# Altium schematic sheet main.SchDoc
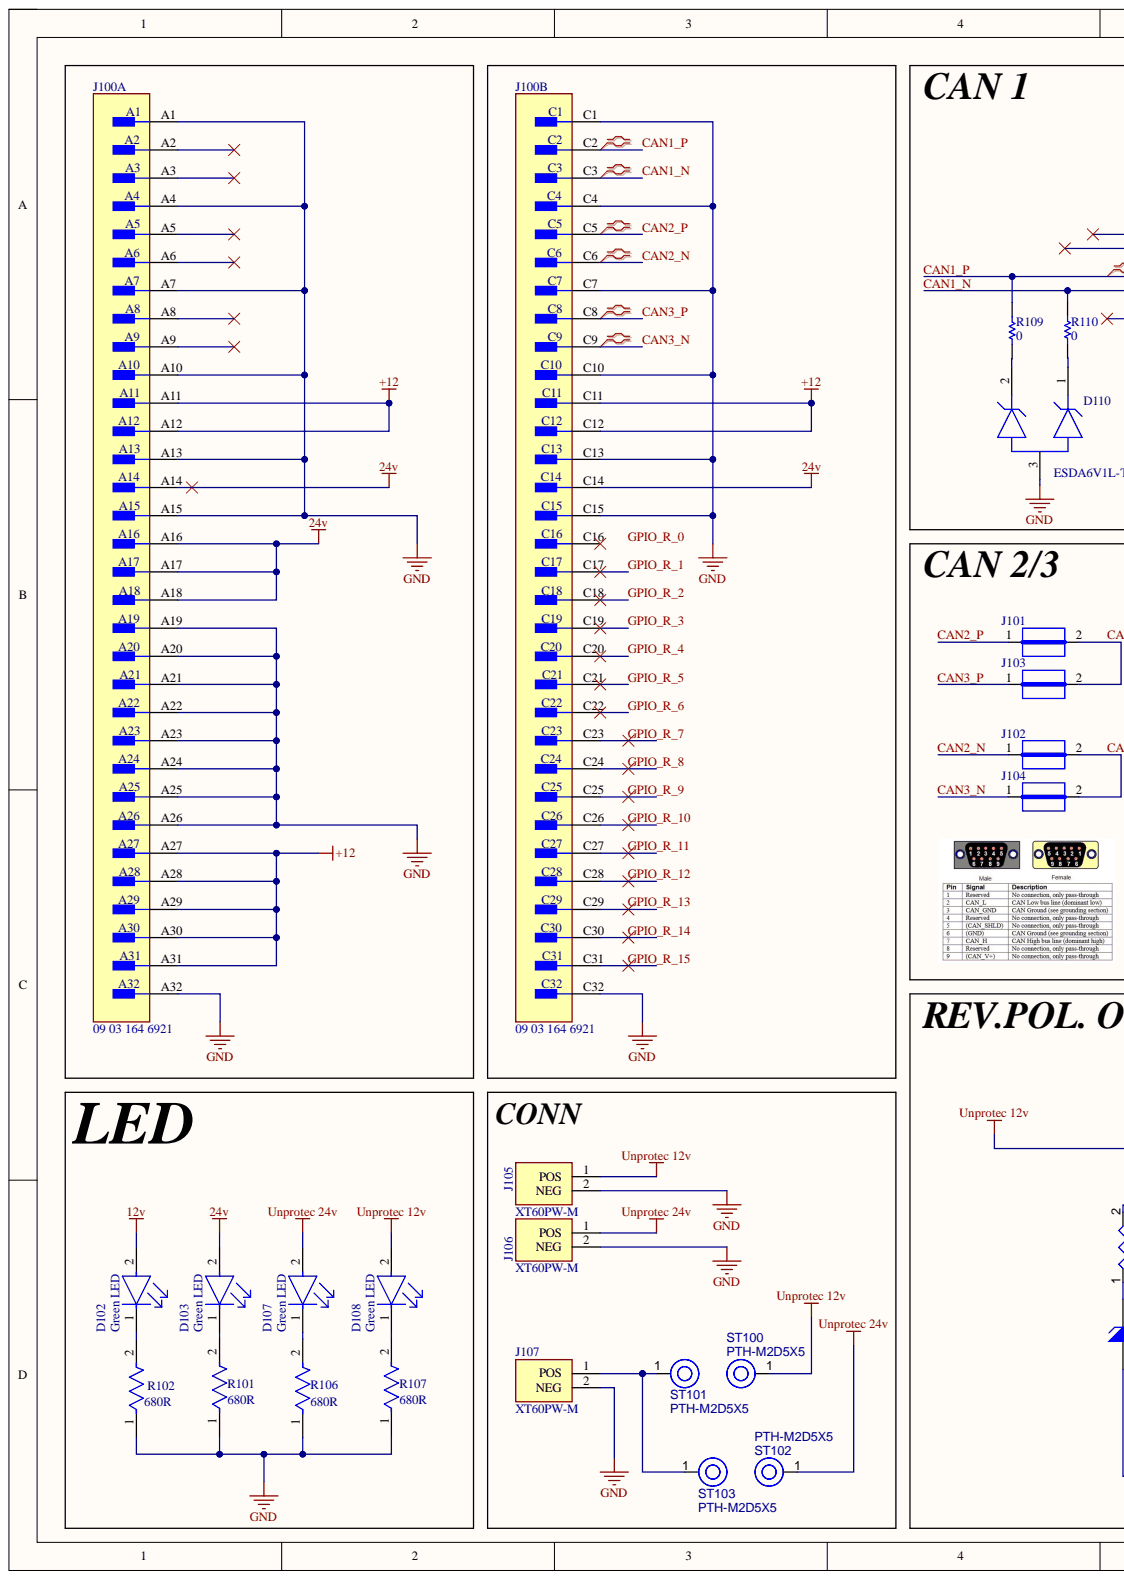
[diagram p0: main.SchDoc, left]
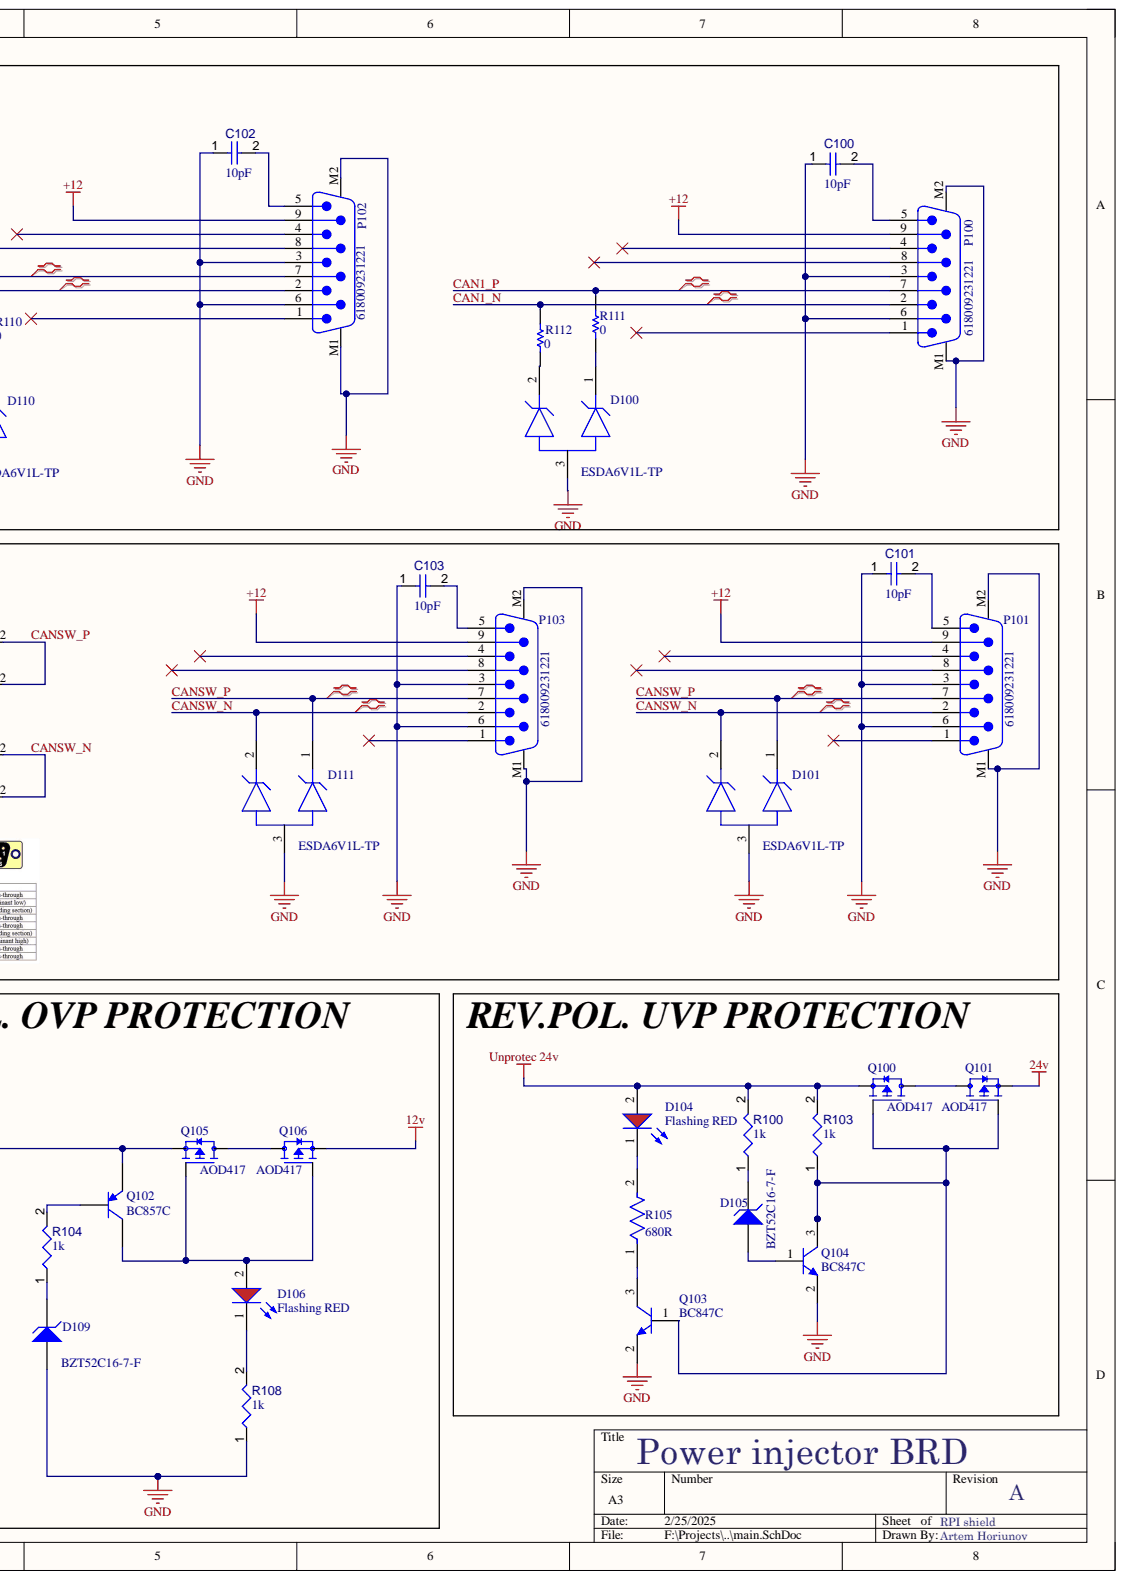
[diagram p1: main.SchDoc, right]

## Parts
C100  value 10pF  [CAPC1005X55X30LL05T10]  (hidden comment: Capacitor 10 pF +/- 0.25 pF 50 V 0402)
C101  value 10pF  [CAPC1005X55X30LL05T10]  (hidden comment: Capacitor 10 pF +/- 0.25 pF 50 V 0402)
C102  value 10pF  [CAPC1005X55X30LL05T10]  (hidden comment: Capacitor 10 pF +/- 0.25 pF 50 V 0402)
C103  value 10pF  [CAPC1005X55X30LL05T10]  (hidden comment: Capacitor 10 pF +/- 0.25 pF 50 V 0402)
D100  ESDA6V1L-TP  [FP-SOT-23-MFG]
D101  ESDA6V1L-TP  [FP-SOT-23-MFG]
D102  Green LED  Colour: Green  [FP-HSME-C191-MFG]
D103  Green LED  Colour: Green  [FP-HSME-C191-MFG]
D104  Flashing RED  [WL-SMCW_0805_150080xx75000]
D105  BZT52C16-7-F  [DIOD-SOD123-2_V]
D106  Flashing RED  [WL-SMCW_0805_150080xx75000]
D107  Green LED  Colour: Green  [FP-HSME-C191-MFG]
D108  Green LED  Colour: Green  [FP-HSME-C191-MFG]
D109  BZT52C16-7-F  [DIOD-SOD123-2_V]
D110  ESDA6V1L-TP  [FP-SOT-23-MFG]
D111  ESDA6V1L-TP  [FP-SOT-23-MFG]
J100  09 03 164 6921  [FP-09_03_164_6921-MFG]  (parts A,B)
J101  JUMPER  [JUMPER]  (value not drawn)
J102  JUMPER  [JUMPER]  (value not drawn)
J103  JUMPER  [JUMPER]  (value not drawn)
J104  JUMPER  [JUMPER]  (value not drawn)
J105  XT60PW-M  [AMASS_XT60PW-M]
J106  XT60PW-M  [AMASS_XT60PW-M]
J107  XT60PW-M  [AMASS_XT60PW-M]
P100  618009231221  [618009231221]
P101  618009231221  [618009231221]
P102  618009231221  [618009231221]
P103  618009231221  [618009231221]
Q100  AOD417  [DPAK228P994X240_3N]
Q101  AOD417  [DPAK228P994X240_3N]
Q102  BC857C  [INF-SOT23_N]
Q103  BC847C  [INF-SOT23_L]
Q104  BC847C  [INF-SOT23_L]
Q105  AOD417  [DPAK228P994X240_3N]
Q106  AOD417  [DPAK228P994X240_3N]
R100  value 1k  [RESC1608X55X25ML10T15]  (hidden comment: Resistor 1k +/-1% 0603 100 mW)
R101  680R  [FP-CRCW0603-e3-MFG]
R102  680R  [FP-CRCW0603-e3-MFG]
R103  value 1k  [RESC1608X55X25ML10T15]  (hidden comment: Resistor 1k +/-1% 0603 100 mW)
R104  value 1k  [RESC1608X55X25ML10T15]  (hidden comment: Resistor 1k +/-1% 0603 100 mW)
R105  680R  [FP-CRCW0603-e3-MFG]
R106  680R  [FP-CRCW0603-e3-MFG]
R107  680R  [FP-CRCW0603-e3-MFG]
R108  value 1k  [RESC1608X55X25ML10T15]  (hidden comment: Resistor 1k +/-1% 0603 100 mW)
R109  value 0  [RESC1005X40X25ML05T10]  (hidden comment: Resistor 0R 0402 63 mW)
R110  value 0  [RESC1005X40X25ML05T10]  (hidden comment: Resistor 0R 0402 63 mW)
R111  value 0  [RESC1005X40X25ML05T10]  (hidden comment: Resistor 0R 0402 63 mW)
R112  value 0  [RESC1005X40X25ML05T10]  (hidden comment: Resistor 0R 0402 63 mW)
ST100  PTH-M2D5X5  [PTH-M2D5X5]
ST101  PTH-M2D5X5  [PTH-M2D5X5]
ST102  PTH-M2D5X5  [PTH-M2D5X5]
ST103  PTH-M2D5X5  [PTH-M2D5X5]

## Nets
12v: D102.2 J100.A11 J100.A12 J100.A27 J100.A28 J100.A29 J100.A30 J100.A31 J100.C11 J100.C12 P100.9 P101.9 P102.9 P103.9 Q106.3
24v: D103.2 J100.A14 J100.A16 J100.A17 J100.A18 J100.C14 Q101.3
GND: C100.1 C101.1 C102.1 C103.1 D100.3 D101.3 D109.1 D110.3 D111.3 J100.A1 J100.A4 J100.A7 J100.A10 J100.A13 J100.A15 J100.A19 J100.A20 J100.A21 J100.A22 J100.A23 J100.A24 J100.A25 J100.A26 J100.A32 J100.C1 J100.C4 J100.C7 J100.C10 J100.C13 J100.C15 J100.C32 J105.2 J106.2 J107.2 P100.3 P100.6 P100.M1 P100.M2 P101.3 P101.6 P101.M1 P101.M2 P102.3 P102.6 P102.M1 P102.M2 P103.3 P103.6 P103.M1 P103.M2 Q103.2 Q104.2 R101.1 R102.1 R106.1 R107.1 R108.1
Unprotec 12v: D108.2 J105.1 Q102.2 Q105.3 ST100.1
Unprotec 24v: D104.2 D107.2 J106.1 Q100.3 R100.2 R103.2 ST102.1
CAN1_N: J100.C3 P100.2 P102.2 R110.2 R112.2
CAN1_P: J100.C2 P100.7 P102.7 R109.2 R111.2
CAN2_N: J100.C6 J102.1
CAN2_P: J100.C5 J101.1
CAN3_N: J100.C9 J104.1
CAN3_P: J100.C8 J103.1
CANSW_N: D101.2 D111.2 J102.2 J104.2 P101.2 P103.2
CANSW_P: D101.1 D111.1 J101.2 J103.2 P101.7 P103.7
GPIO_R_1: J100.C17
GPIO_R_2: J100.C18
GPIO_R_3: J100.C19
GPIO_R_4: J100.C20
GPIO_R_5: J100.C21
GPIO_R_6: J100.C22
GPIO_R_7: J100.C23
GPIO_R_8: J100.C24
GPIO_R_9: J100.C25
GPIO_R_10: J100.C26
GPIO_R_11: J100.C27
GPIO_R_12: J100.C28
GPIO_R_13: J100.C29
GPIO_R_14: J100.C30
GPIO_R_15: J100.C31
NetC100_2: C100.2 P100.5
NetC101_2: C101.2 P101.5
NetC102_2: C102.2 P102.5
NetC103_2: C103.2 P103.5
NetD100_1: D100.1 R111.1
NetD100_2: D100.2 R112.1
NetD102_1: D102.1 R102.2
NetD103_1: D103.1 R101.2
NetD104_1: D104.1 R105.2
NetD105_1: D105.1 Q104.1
NetD105_2: D105.2 R100.1
NetD106_1: D106.1 R108.2
NetD106_2: D106.2 Q102.3 Q105.1 Q106.1
NetD107_1: D107.1 R106.2
NetD108_1: D108.1 R107.2
NetD109_2: D109.2 R104.1
NetD110_1: D110.1 R110.1
NetD110_2: D110.2 R109.1
NetJ107_1: J107.1 ST101.1 ST103.1
NetQ100_1: Q100.1 Q101.1 Q103.1 Q104.3 R103.1
NetQ100_4: Q100.4 Q101.4
NetQ102_1: Q102.1 R104.2
NetQ103_3: Q103.3 R105.1
NetQ105_4: Q105.4 Q106.4
GPIO_R_0:

Not connected: J100 A2 A3 A5 A6 A8 A9 C16; P100 1 4 8; P101 1 4 8; P102 1 4 8; P103 1 4 8

## Library data of the parts
C100-C103 = CMP-001-00011-6: Chip Capacitor, 10 pF, +/- 0.25 pF, 50 V, 0402 (1005 Metric); Capacitor Type: Ceramic; Tolerance: 0.25%; Voltage Rating: 50V; Case/Package: 0402; Component Kind: Standard; Max Operating Temperature: 125°C; Min Operating Temperature: -55°C; Mounting Technology: SM; Pins: 2; RoHS Compliant: True; Library Name: WUST
D100 D101 D110 D111 = CMP-56244-000002-1: TVS DIODE 5.25V SOT23; Breakdown Voltage: 6.65V; Capacitance: 140pF; Direction: Unidirectional; Element Configuration: Common Anode; Leakage Current: 20uA; Max Breakdown Voltage: 7.2V; Min Breakdown Voltage: 6.1V; Number of Channels: 2; Peak Pulse Power: 300W; Power Line Protection: No; Case/Package: SOT-23; Component Kind: Standard; Composition: Zener; Depth: 1.4mm; Lead Free: Lead Free; Length: 3.04mm; Library Name: Altium Content Vault; Max Operating Temperature: 150°C; Min Operating Temperature: -55°C; Mount: Surface Mount; Number of Pins: 3; Number of Unidirectional Channels: 2; Package Quantity: 1; Packaging: Tape and Reel; Power Dissipation: 300W; Radiation Hardening: No; Reverse Standoff Voltage: 5.25V; Termination: SMD/SMT; Working Voltage: 5.25V
D102 D103 D107 D108 = CMP-18399-000013-1: LED GREEN DIFFUSED 0603 SMD; Manufacturer: Broadcom; Manufacturer Part Number: HSME-C191; Forward Current: 20mA; Forward Voltage: 2.1V; Led Orientation: Top View; Luminous Intensity: 50mcd; Max Junction Temp: +95°C; Peak Emmision Wavelength: 570nm; Power Dissipation: 52mW; Reverse Voltage: 5V; Viewing Angle: 170°; Wavelength: 572nm; Automotive: No; Category: Diode; Component Kind: Standard; ComponentLink2Description: Datasheet; ComponentLink2URL: https://docs.broadcom.com/docs/AV02-0976EN; Device Class L1: Optoelectronics; Device Class L2: LEDs; Device Class L3: unset; Digikey Description: LED GREEN DIFFUSED 0603 SMD; Height: 0.7mm; Lead Free: Yes; Library Name: Altium Content Vault; Mouser Description: Standard LEDs - SMD AlInGaP Green; Octopart: https://octopart.com/hsme-c191-broadcom-71131261; Package: 0; RoHS: TRUE; Temperature: -40°C to +85°C; Temperature Range High: +85°C; Temperature Range Low: -40°C
D104 D106 = CMP-1426-00010-4: Led, Red, 630 Nm, 1.9 V, 30 Ma, 60 Mcd Rohs Compliant: Yes; Dominant Wavelength: 630nm; Forward Current: 100mA; Forward Voltage: 1.9V; Lens Style: Clear, Rectangular; Luminous Intensity: 60mcd; Max Junction Temperature (Tj): 95°C; Max Reverse Voltage (DC): 5V; Peak Wavelength: 645nm; Power Dissipation: 72mW; Test Current: 20mA; Viewing Angle: 140°; Case Code (Imperial): 0805; Case Code (Metric): 2012; Case/Package: 0805; Color: Red; Component Kind: Standard; Height: 700µm; Length: 2mm; Library Name: Altium Content Vault; Max Operating Temperature: 85°C; Min Operating Temperature: -40°C; Mount: Surface Mount; Packaging: Tape and Reel; REACH SVHC: Unknown; Reverse Voltage: 5V; RoHS: Compliant; Wavelength: 630nm; Width: 1.25mm
D105 D109 = CMP-0860-00001-1: Surface Mount Zener Diode, 16 V, -65 to 125 degC, SOD123, RoHS, Tape and Reel; Manufacturer: Diodes Inc; Maximum Reverse Current: 0.1 uA; Maximum Zener Impedance f: 1 kHz ZZK=200 Ohm; Power Max: 500 mW; Tol V: 5.56% Typ.; Voltage Zener: 16 V Typ.; Component Kind: Standard; ComponentLink1Description: Manufacturer URL; ComponentLink1URL: http://www.diodes.com/; ComponentLink2Description: Datasheet; ComponentLink2URL: http://www.diodes.com/datasheets/ds18004.pdf; ComponentLink3Description: Package Specification; ComponentLink3URL: http://www.diodes.com/datasheets/ap02002.pdf; DatasheetVersion: Rev. 37-2; Library Name: Altium Content Vault; Mounting Technology: Surface Mount; Operating Temperature: -65 to 150 degC; PackageDescription: 2-Pin SOD123, Body 2.7 x 1.55 mm, Height 1.45 mm; PackageReference: SOD123; PackageVersion: Rev. 79; Packing: Tape and Reel; PartNumber: BZT52C16-7-F; RoHS: TRUE
J100 = CMP-33111-000001-1: CONN DIN HDR 96POS PCB RA; Manufacturer: Harting; Conn Gender: Male; Conn Orientation: Right Angle; Current Rating: 2A; Number Of Contacts: 64; Pitch: 2.54mm; Automotive: No; Category: Conn; Component Kind: Standard; ComponentLink2Description: Datasheet; ComponentLink2URL: https://b2b.harting.com/files/download/PRD/PDF_DS/09031200203_100580339DRW000B.pdf; Contact Material: Gold,Nickel; Device Class L1: Connectors; Device Class L2: Backplane Connectors; Device Class L3: unset; Digikey Description: CONN DIN HDR 96POS PCB RA; Footprint Url: https://b2b.harting.com/files/download/PRD/PDF_TS/0903164X921_100072506DRW176A.pdf; Height: 11mm; Lead Free: Yes; Library Name: Altium Content Vault; Manufacturer Part Number: 09 03 164 6921; Mouser Description: Conn DIN 41612 M 64 POS 2.54mm Solder RA Thru-Hole Box; Number Of Rows: 2; Octopart: https://octopart.com/09031646921-harting+elektronik-42933; Package: 0; RoHS: TRUE; Temperature: -55°C to +125°C; Temperature Range High: +125°C; Temperature Range Low: -55°C
J101-J104 = CMP-009-00061-2: Component Kind: Standard; Description: JUMPER; Pins: 2; Library Name: WUST
J105-J107 = CMP-002-00072-1: Socket, DC supply, male, PIN: 2; MF: AMASS; Availability: Not in stock; Case/Package: Package; Check_prices: https://www.snapeda.com/parts/XT60PW-M/AMASS/view-part/?ref=eda; Component Kind: Standard; Component Type: Standard; Design Item ID: XT60PW-M; MP: XT60PW-M; Pins: 2; Price: None; SnapEDA_Link: https://www.snapeda.com/parts/XT60PW-M/AMASS/view-part/?ref=snap; Source: XT60PW-M.SchLib; Library Name: WUST
P100-P103 = CMP-1502-00284-2: NexarPartId: 22865742; Contact Resistance: 30mΩ; Current Rating: 3A; Gender: Male; Insulation Resistance: 5GΩ; Number of Contacts: 9; Operating Supply Voltage: 125V; Pitch: 2.77mm; Component Kind: Standard; Contact Material: Gold; Contact Plating: Gold; Depth: 18.5mm; Height: 12.7mm; Housing Material: Steel; Insulation Material: PBT; Length: 30.8mm; Library Name: Altium Content Vault; Max Operating Temperature: 105°C; Min Operating Temperature: -55°C; Mount: Through Hole; Number of Pins: 9; Number of Rows: 2; Orientation: Right Angle; REACH SVHC: Unknown; RoHS: Compliant; Termination: SMD/SMT; Voltage Rating: 125V; Width: 12.5mm
Q100 Q101 Q105 Q106 = AOD417: P-Channel 30V 25A _Ta_ 2.5W _Ta_, 50W _Tc_ Surface Mount TO-252, _D-Pak_; MF: Alpha &; Availability: Unavailable; Component Kind: Standard; Library Name: AOD417(1).IntLib; MP: AOD417; Package: TO-252 Alpha &amp; Omega Semiconductor; Price: None; SnapEDA_Link: https://www.snapeda.com/parts/AOD417/Alpha%20%26%20Omega%20Semiconductor%20Inc./view-part/454934/?ref=snap
Q102 = CMP-1177-00039-1: PNP Silicon AF Transistor, 45 V VCEO, 100 mA IC, SOT23, Reel, Green; Manufacturer: Infineon; fT (typ): 250Â MHz; Green: TRUE; IBM (max): 200Â mA; IC (max): 100Â mA; ICBO (max): 15Â nA; Ptot (max): 330Â mW; VCBO (max): 50Â V; VCEO (max): 45Â V; VEBO (max): 5Â V; Component Kind: Standard; ComponentLink1Description: Manufacturer URL; ComponentLink1URL: http://www.infineon.com/; ComponentLink2Description: Datasheet; ComponentLink2URL: http://www.infineon.com/dgdl/bc857series_bc858series_bc859series_bc860series.pdf?folderId=db3a304314dca389011541d30fa21656&fileId=db3a304314dca389011541da0e3a1661; ComponentLink3Description: Package Specification; ComponentLink3URL: http://www.infineon.com/dgdl/SOT23-Package_Overview.pdf?folderId=db3a30431936bc4b0119532290053c66&fileId=db3a30431936bc4b0119533468123c85; ICM (max): 200Â mA; Library Name: Altium Content Vault; Mounting Technology: Surface Mount; PackageDescription: 3-Pin Small Outline Transistor Package, 1.3 x 2.9 mm Body, 0.95 mm Pitch; PackageReference: SOT23; PackageVersion: Apr-08; Packing: Reel; PartNumber: BC857C; Polarity: PNP
Q103 Q104 = CMP-1177-00037-1: NPN Silicon AF Transistor, 45 V VCEO, 100 mA IC, SOT23, Reel, Green; Manufacturer: Infineon; fT (typ): 250Â MHz; Green: TRUE; IBM (max): 200Â mA; IC (max): 100Â mA; ICBO (max): 15Â nA; Ptot (max): 330Â mW; VCBO (max): 50Â V; VCEO (max): 45Â V; VEBO (max): 6Â V; Component Kind: Standard; ComponentLink1Description: Manufacturer URL; ComponentLink1URL: http://www.infineon.com/; ComponentLink2Description: Datasheet; ComponentLink2URL: http://www.infineon.com/dgdl/bc847series_bc848series_bc849series_bc850series.pdf?folderId=db3a304314dca389011541d30fa21656&fileId=db3a304314dca389011541d4630a1657; ComponentLink3Description: Package Specification; ComponentLink3URL: http://www.infineon.com/dgdl/SOT23-Package_Overview.pdf?folderId=db3a30431936bc4b0119532290053c66&fileId=db3a30431936bc4b0119533468123c85; ICM (max): 200Â mA; Library Name: Altium Content Vault; Mounting Technology: Surface Mount; PackageDescription: 3-Pin Small Outline Transistor Package, 1.3 x 2.9 mm Body, 0.95 mm Pitch; PackageReference: SOT23; PackageVersion: Apr-08; Packing: Reel; PartNumber: BC847C; Polarity: NPN
R100 R103 R104 R108 = CMP-009-00014-5: Chip Resistor, 1 KOhm, +/-1%, 0.1 W, -55 to 155 degC, 0603 (1608 Metric); Power: 100mW; Tolerance: 1%; Voltage Rating: 50V; Case/Package: 0603; Component Kind: Standard; Max Operating Temperature: 155°C; Min Operating Temperature: -55°C; Mounting Technology: SM; Pins: 2; RoHS Compliant: True; Library Name: WUST
R101 R102 R105-R107 = CMP-2000-03529-2: RES Thick Film, 680Ω, 1%, 0.1W, 100ppm/°C, 0603; Max Power Dissipation: 100mW; Voltage Rating: 75V; Case Code (Imperial): 0603; Case Code (Metric): 1608; Case/Package: 0603; Component Kind: Standard; Composition: Thick Film; Contact Plating: Tin; Depth: 0.85mm; Features: Automotive AEC-Q200; Height: 0.45mm; Lead Free: Lead Free; Length: 1.55mm; Library Name: Altium Content Vault; Max Operating Temperature: 155°C; Military Standard: Not; Min Operating Temperature: -55°C; Number of Pins: 2; Number of Terminations: 2; Package Quantity: 10; Packaging: Tape and Reel; Power Rating: 100mW; Radiation Hardening: No; Resistance: 680R; Temperature Coefficient: 100ppm/°C; Termination: SMD/SMT; Tolerance: 1%; Voltage Rating (DC): 75V; Weight: 7.1E-05oz; Width: 0.85mm; Working Voltage: 75V
R109-R112 = CMP-009-00004-5: Chip Resistor, 0 Ohm, 0.063 W, -55 to 155 degC, 0402 (1005 Metric); Power: 63mW; Voltage Rating: 50V; Case/Package: 0402; Component Kind: Standard; Max Operating Temperature: 155°C; Min Operating Temperature: -55°C; Mounting Technology: SM; Pins: 2; RoHS Compliant: True; Library Name: WUST
ST100-ST103 = CMP-00028-00004-2: M2 PTH (2.5mm), 5mm pad; Component Kind: Standard; Pins: 1; Library Name: WUST
